# Altium schematic sheet Sheet2.SchDoc(Sheet2)
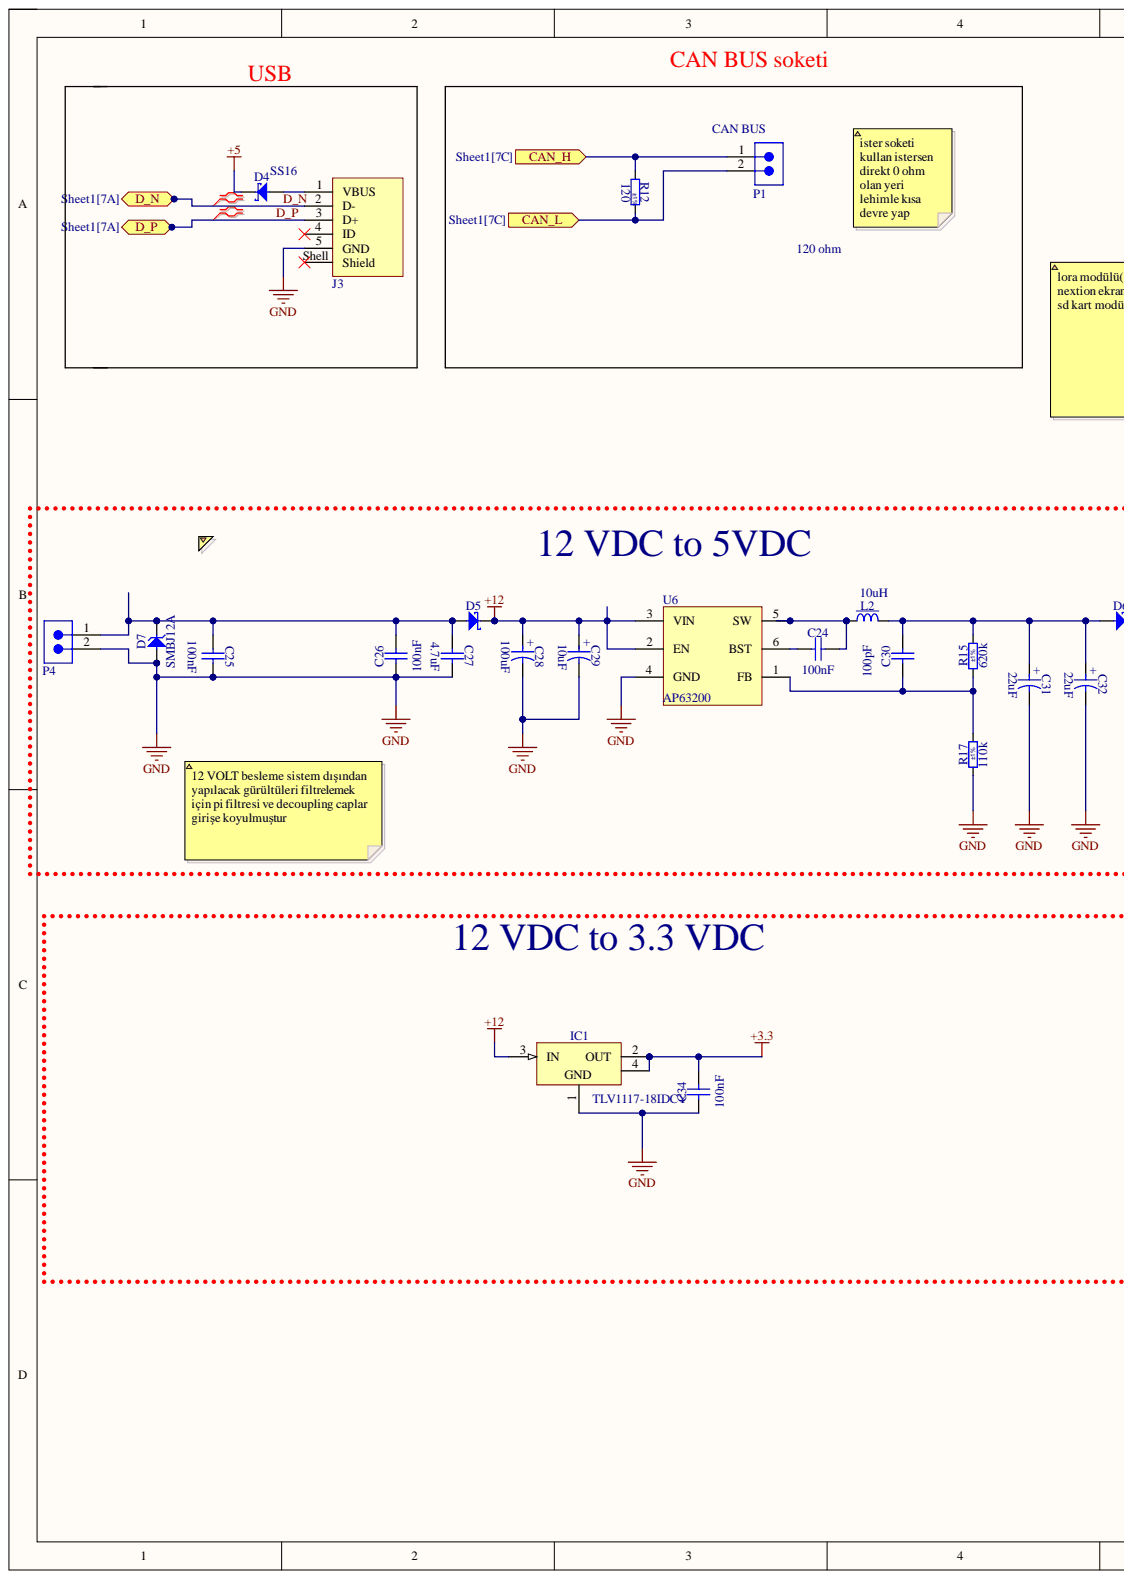
[diagram p0: Sheet2.SchDoc, left]
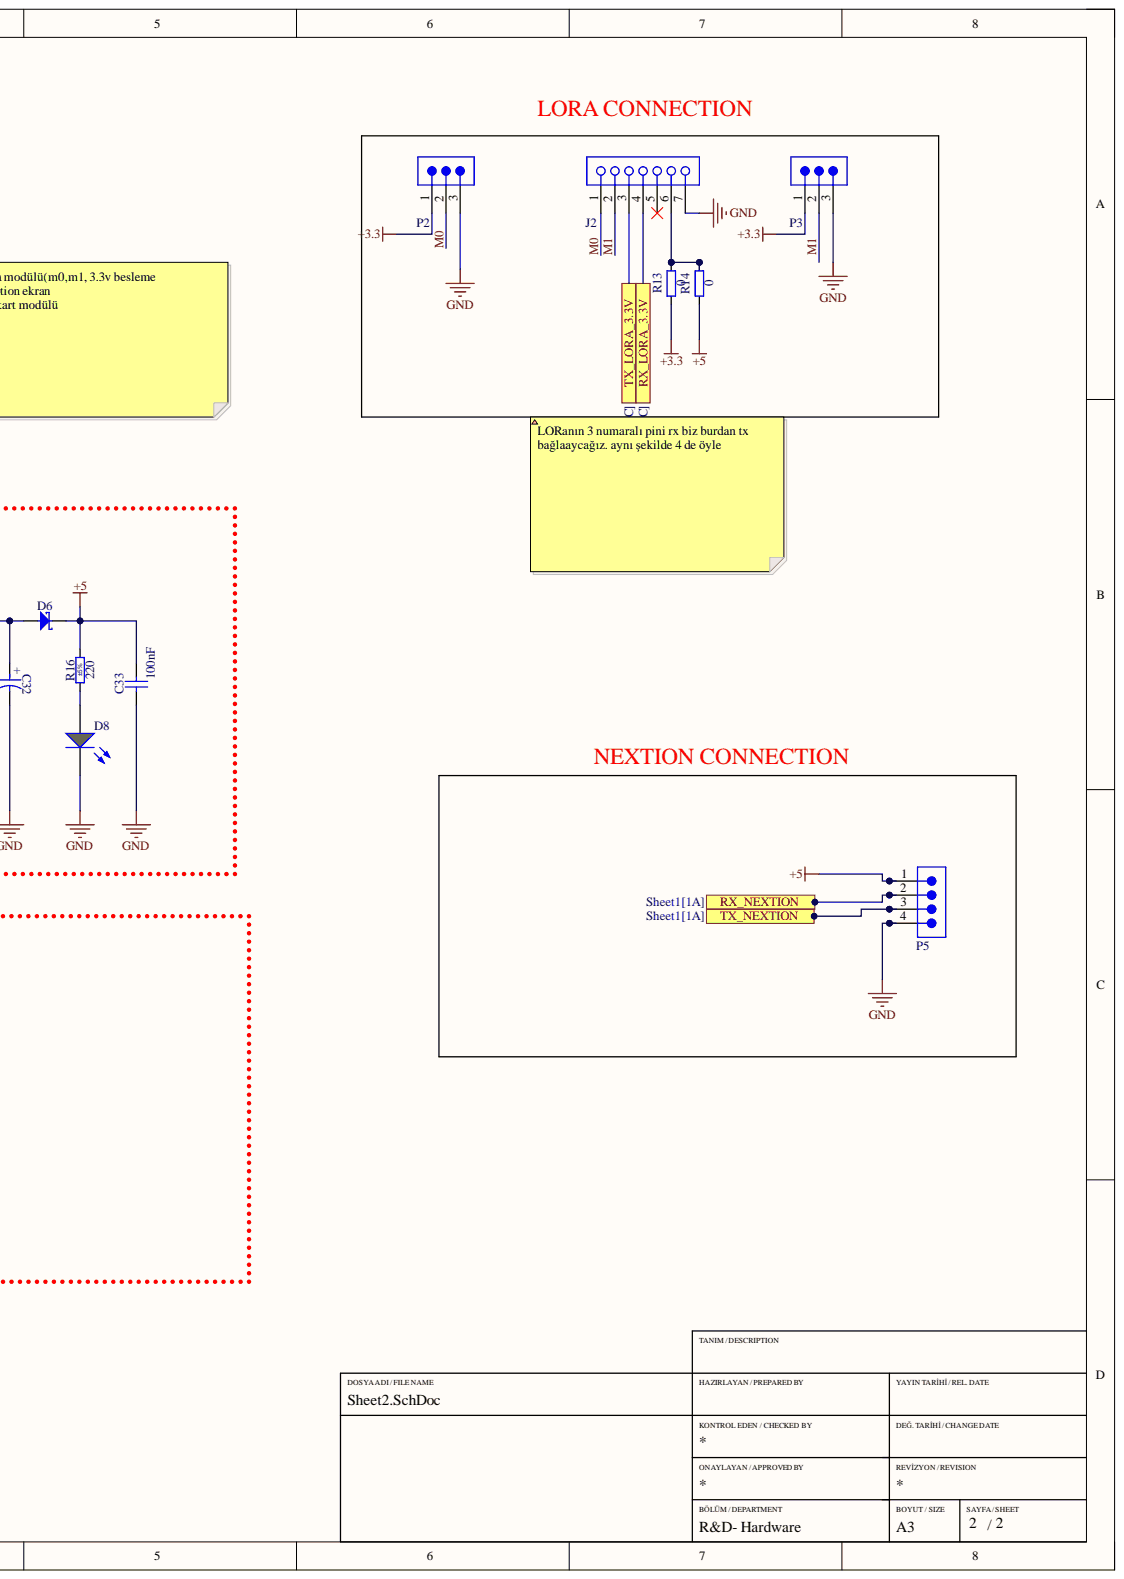
[diagram p1: Sheet2.SchDoc, right]

## Parts
C24  100nF  [CAPC2012X135N]
C25  100nF  [CAPC2012X135N]
C26  100nF  [CAPC2012X135N]
C27  4.7uF  [CAPC2012X135N]
C28  100uF  [CAPPR250-680X1250]
C29  10uF  [CAPPR250-680X1250]
C30  100pF  [CAPC2012X135N]
C31  22uF  [CAPPR250-500X1100]
C32  22uF  [CAPPR250-500X1100]
C33  100nF  [CAPC2012X135N]
C34  100nF  [CAPC2012X135N]
D4  SS16  [DIOM5127X229N]
D5  SS16  [DIOM5127X229N]  (value not drawn)
D6  SS16  [DIOM5127X229N]  (value not drawn)
D7  SMBJ12A  [DIOM5336X265N]
D8  Green, 3.2V, 140°  [WL-SMCW_0805_150080xx75000]  (value not drawn)
IC1  TLV1117-18IDCY  [SOT230P700X180-4N]
J2  61300711821  [61300711821]  (value not drawn)
J3  65100516121  [65100516121]  (value not drawn)
L2  10uH  [IND_TRACO_TCK-047]
P1  691312510002  [691312510002]  (value not drawn)
P2  61300311121  [61300311121]  (value not drawn)
P3  61300311121  [61300311121]  (value not drawn)
P4  691312410002  [691312410002]  (value not drawn)
P5  691312510004  [691312510004]  (value not drawn)
R12  120  Tolerance: ±1%  [RESC2012X60N]
R13  0  [RESC2012X60N]
R14  0  [RESC2012X60N]
R15  620k  Tolerance: ±1%  [RESC2012X60N]
R16  220  Tolerance: ±5%  [RESC2012X60N]
R17  110k  Tolerance: ±1%  [RESC2012X60N]
U6  AP63200  [TSOT-26]

## Ports
CAN_H, CAN_L, D_N, D_P, RX_LORA_3.3V, RX_NEXTION, TX_LORA_3.3V, TX_NEXTION

## Nets
+3.3: C34.2 IC1.2(OUT) IC1.4 P2.1 P3.1 R13.1
+5: C33.2 D4.1 D6.1 P5.1 R14.1 R16.2
+12: C28.1 C29.1 D5.1 IC1.3(IN) U6.2(EN) U6.3(VIN)
GND: C25.2 C26.1 C27.2 C28.2 C29.2 C31.2 C32.2 C33.1 C34.1 D7.2 D8.1 IC1.1 J2.7 J3.5(GND) P2.3 P3.3 P4.2 P5.4 R17.1 U6.4(GND)
CAN_H: P1.1 R12.1 ; port CAN_H
CAN_L: P1.2 R12.2 ; port CAN_L
D_N: J3.2(D-) ; port D_N
D_P: J3.3(D+) ; port D_P
M0: J2.1 P2.2
M1: J2.2 P3.2
RX_LORA_3.3V: J2.4 ; port RX_LORA_3.3V
RX_NEXTION: P5.2 ; port RX_NEXTION
TX_LORA_3.3V: J2.3 ; port TX_LORA_3.3V
TX_NEXTION: P5.3 ; port TX_NEXTION
NetC24_1: C24.1 U6.6(BST)
NetC24_2: C24.2 L2.1 U6.5(SW)
NetC25_1: C25.1 C26.2 C27.1 D5.2 D7.1 P4.1
NetC30_1: C30.1 R15.1 R17.2 U6.1(FB)
NetC30_2: C30.2 C31.1 C32.1 D6.2 L2.2 R15.2
NetD4_2: D4.2 J3.1(VBUS)
NetD8_2: D8.2 R16.1
NetJ2_6: J2.6 R13.2 R14.2

Not connected: J2 5; J3 4(ID) Shell(Shield)

## Library data of the parts
C24-C26 C33 C34 = CC0805_100NF_50V_10%_X7R: Non-polarised capacitor; Manufacturer: GENERIC; Manufacturer1 Part Number: C2012X7R1H104K085; Part Description: SMD Multilayer Chip Ceramic Capacitor; Database Table Name: Capacitors SMD; TC: X7R; Tolerance: ±10%; Voltage: 50V; Case: 0805; Component Kind: Standard; Component Type: Standard; CreateDate: 3.12.2007; HelpURL: \\cern.ch\dfs\Applications\Altium\Datasheets\CC0805_X7R_TDK_C.pdf; LatestRevisionDate: 22.04.2015; Library Name: Capacitors.DbLib; Manufacturer1 ComponentHeight: 1mm; Manufacturer1 Example: TDK; Manufacturer Part Number: CC0805_100NF_50V_10%_X7R; Mounted: Yes; Part Number: CC0805_100NF_50V_10%_X7R; Pin Count: 2; Sense: No; SMD: Yes; Socket: No; Status: None; Value: 100nF; Author: CERN DEM JLC
C27 = CC0805_4.7UF_10V_10%_X5R: Non-polarised capacitor; Manufacturer: GENERIC; Manufacturer1 Part Number: 222224013672; Part Description: SMD Multilayer Chip Ceramic Capacitor; Database Table Name: Capacitors SMD; TC: X5R; Tolerance: ±10%; Voltage: 10V; Case: 0805; Component Kind: Standard; Component Type: Standard; CreateDate: 3.12.2007; HelpURL: \\cern.ch\dfs\Applications\Altium\Datasheets\CC0805_X5R_PHYCOMP_HC.pdf; LatestRevisionDate: 3.12.2007; Library Name: Capacitors.DbLib; Manufacturer1 ComponentHeight: 1.45mm; Manufacturer1 Example: PHYCOMP; Manufacturer Part Number: CC0805_4.7UF_10V_10%_X5R; Mounted: Yes; Part Number: CC0805_4.7UF_10V_10%_X5R; Pin Count: 2; Sense: No; SMD: Yes; Socket: No; Status: Preferred; Value: 4.7uF; Author: CERN DEM JLC
C28 = CAER_100UF_25V_20%_NICHICON_UPS1E101MED: Polarised capacitor; Part Description: Aluminium Electrolytic Capacitor (UPS Series); Database Table Name: Capacitors THD; Tolerance: ±20%; Voltage: 25V; Case: LS2.5 D6.3 x H11; Component Kind: Standard; Component Type: Standard; ComponentHeight: 12.5mm; CreateDate: 30.05.2016; HelpURL: \\cern.ch\dfs\Applications\Altium\Datasheets\CAER_NICHICON_UPS.pdf; LatestRevisionDate: 30.05.2016; Library Name: Capacitors.DbLib; Manufacturer: NICHICON; Manufacturer Part Number: UPS1E101MED; Mounted: Yes; Part Number: CAER_100UF_25V_20%_NICHICON_UPS1E101MED; Pin Count: 2; Sense: No; SMD: No; Socket: No; Status: None; Value: 100uF; Author: CERN DEM MR
C29 = CAER_100UF_25V_20%_NICHICON_UPS1E101MED: Polarised capacitor; Part Description: Aluminium Electrolytic Capacitor (UPS Series); Part Number: CAER_100NF_25V_20%_NICHICON_UPS1E101MED; Database Table Name: Capacitors THD; Tolerance: ±20%; Voltage: 25V; Case: LS2.5 D6.3 x H11; Component Kind: Standard; Component Type: Standard; ComponentHeight: 12.5mm; CreateDate: 30.05.2016; HelpURL: \\cern.ch\dfs\Applications\Altium\Datasheets\CAER_NICHICON_UPS.pdf; LatestRevisionDate: 30.05.2016; Library Name: Capacitors.DbLib; Manufacturer: NICHICON; Manufacturer Part Number: UPS1E101MED; Mounted: Yes; Pin Count: 2; Sense: No; SMD: No; Socket: No; Status: None; Value: 10uF; Author: CERN DEM MR
C30 = CC0805_100PF_50V_5%_NP0: Non-polarised capacitor; Manufacturer: GENERIC; Manufacturer1 Part Number: 223886115101; Part Description: SMD Multilayer Chip Ceramic Capacitor; Database Table Name: Capacitors SMD; TC: C0G/NP0; Tolerance: ±5%; Voltage: 50V; Case: 0805; Component Kind: Standard; Component Type: Standard; CreateDate: 3.12.2007; HelpURL: \\cern.ch\dfs\Applications\Altium\Datasheets\CC0805_NP0_PHYCOMP.pdf; LatestRevisionDate: 3.12.2007; Library Name: Capacitors.DbLib; Manufacturer1 ComponentHeight: 1.35mm; Manufacturer1 Example: PHYCOMP; Manufacturer Part Number: CC0805_100PF_50V_5%_NP0; Mounted: Yes; Part Number: CC0805_100PF_50V_5%_NP0; Pin Count: 2; Sense: No; SMD: Yes; Socket: No; Status: Preferred; Value: 100pF; Author: CERN DEM JLC
C31 = CAER_47UF_25V_20%_250-500X1100: Polarised capacitor; Manufacturer: GENERIC; Manufacturer1 Part Number: EEUFC1E470; Manufacturer Part Number: CAER_22uF_25V_20%_250-500X1100; Part Description: Aluminum Electrolytic Capacitor; Database Table Name: Capacitors THD; Tolerance: ±20%; Voltage: 25V; Case: LS2.5 D5 x H11; Component Kind: Standard; Component Type: Standard; ComponentHeight: 11mm; CreateDate: 18.12.2007; HelpURL: \\cern.ch\dfs\Applications\Altium\Datasheets\CAER_PANASONIC_FC.pdf; LatestRevisionDate: 18.12.2007; Library Name: Capacitors.DbLib; Manufacturer1 ComponentHeight: 11mm; Manufacturer1 Example: PANASONIC; Mounted: Yes; Part Number: CAER_22uF_25V_20%_250-500X1100; Pin Count: 2; Sense: No; SMD: No; Socket: No; Status: Preferred; Value: 22uF; Author: CERN DEM JLC
C32 = CAER_47UF_25V_20%_250-500X1100: Polarised capacitor; Manufacturer: GENERIC; Manufacturer1 Part Number: EEUFC1E470; Manufacturer Part Number: CAER_22pF_25V_20%_250-500X1100; Part Description: Aluminum Electrolytic Capacitor; Part Number: CAER_22uF_25V_20%_250-500X1100; Database Table Name: Capacitors THD; Tolerance: ±20%; Voltage: 25V; Case: LS2.5 D5 x H11; Component Kind: Standard; Component Type: Standard; ComponentHeight: 11mm; CreateDate: 18.12.2007; HelpURL: \\cern.ch\dfs\Applications\Altium\Datasheets\CAER_PANASONIC_FC.pdf; LatestRevisionDate: 18.12.2007; Library Name: Capacitors.DbLib; Manufacturer1 ComponentHeight: 11mm; Manufacturer1 Example: PANASONIC; Mounted: Yes; Pin Count: 2; Sense: No; SMD: No; Socket: No; Status: Preferred; Value: 22uF; Author: CERN DEM JLC
D4-D6 = SS16: Schottky Diode; Manufacturer: VISHAY GENERAL SEMICONDUCTOR; Part Description: Surface Mount Schottky Barrier Rectifier; Bonding: No; Database Table Name: Diodes; Power: 1A; PressFit: No; Voltage: 60V; Case: DO-214AC; Component Kind: Standard; Component Type: Standard; ComponentHeight: 2.29mm; CreateDate: 15.07.2008; Device: SS16; HelpURL: \\cern.ch\dfs\Applications\Altium\Datasheets\SS16.pdf; LatestRevisionDate: 23.08.2010; Library Name: ICs And Semiconductors.DbLib; Manufacturer Part Number: SS16; Mounted: Yes; PackageDescription: DO-214-AC/SMA Diode, Molded Body; 5.10mm L X 2.67mm W X 2.29mm H, IPC Medium Density; Part Number: SS16; Pin Count: 2; Sense: No; SMD: Yes; Socket: No; Author: CERN DEM JLC
D7 = SMBJ12A: Zener Diode; Manufacturer: FAIRCHILD SEMICONDUCTOR; Part Description: Uni-Directional Transient Voltage Suppressor Diode; Bonding: No; Database Table Name: Diodes; Power: 600W; PressFit: No; Voltage: 12V; Case: DO-214AA; Component Kind: Standard; Component Type: Standard; ComponentHeight: 2.65mm; CreateDate: 10.04.2013; Device: SMBJ12A; HelpURL: \\cern.ch\dfs\Applications\Altium\Datasheets\SMBJ.pdf; LatestRevisionDate: 10.04.2013; Library Name: ICs And Semiconductors.DbLib; Manufacturer Part Number: SMBJ12A; Mounted: Yes; PackageDescription: DO-214-AA/SMB Diode, Molded Body; 5.34mm L X 3.625mm W X 2.65mm H, IPC Medium Density; Part Number: SMBJ12A; Pin Count: 2; Sense: No; SMD: Yes; Socket: No; Author: CERN DEM JLC
D8 = 150080GS75000: WL-SMCW Mono-color Chip LED Waterclear, size 0805, Green, 3.2V, 140°; Manufacturer: Wurth Elektronik; Case/Size Code: 0805; Chip Technology: InGaN; Database Table Name: LEDs; Dominant Wavelength: 525nm; Luminous Intensity: 450mcd; Peak Wavelength: 515nm; Category: Signal LEDs; Component Kind: Standard; ComponentLink1Description: Website Link; ComponentLink1URL: https://www.we-online.com/redexpert/article/150080GS75000?ad; ComponentLink2Description: Datasheet Link; ComponentLink2URL: https://www.we-online.com/redexpert/spec/150080GS75000?ad; Emitting Color: Green; Forward Voltage: 3.2V; Height: 0.7mm; Length: 2mm; Library Name: WE - Optoelectronic Components.DbLib; Manufacturer Part Number: 150080GS75000; Match Code: WL-SMCW; Mount: Surface Mount; Operating Temperature Max: 85°C; Operating Temperature Min: -40°C; Packaging: Tape and Reel; Simulation: 0805_150080GS75000; Viewing Angle: 140°; Width: 1.25mm
IC1 = TLV1117-18IDCY: 3-Terminal Regulator 1=GND 2=OUT 3=IN 4=OUT; Manufacturer: TEXAS INSTRUMENTS; Part Description: 1.8V, 800mA Low-Dropout Voltage Regulator; Bonding: No; Database Table Name: Regulators; PressFit: No; Case: SOT223; Component Kind: Standard; Component Type: Standard; ComponentHeight: 1.8mm; CreateDate: 6.06.2017; Device: TLV1117-18IDCY; HelpURL: \\cern.ch\dfs\Applications\Altium\Datasheets\TLV1117-18IDCY.pdf; LatestRevisionDate: 6.06.2017; Library Name: ICs And Semiconductors.DbLib; Manufacturer Part Number: TLV1117-18IDCY; Mounted: Yes; PackageDescription: SOT223, 2.30mm Pitch; 4 Pin, 3.70mm W X 6.70mm L X 1.80mm H body, IPC Medium Density; Part Number: TLV1117-18IDCY; Pin Count: 4; Sense: No; SMD: Yes; Socket: No; Author: CERN DEM JLC
J2 = 61300711821: WR-PHD Socket Header, THT, Vertical, pitch 2.54mm, 1 Row, 7P; Manufacturer: Wurth Elektronik; Contact Resistance: 20mOhm; Database Table Name: Connectors; Rated Current: 3A; Withstanding Voltage: 500V; Working Voltage: 250V; Category: Board-to-Board Connectors; Component Kind: Standard; ComponentLink1Description: Website Link; ComponentLink1URL: https://www.we-online.com/redexpert/article/61300711821?ad; ComponentLink2Description: Datasheet Link; ComponentLink2URL: https://www.we-online.com/redexpert/spec/61300711821?ad; Gender: Socket Header; Library Name: WE - Electromechanical Components.DbLib; Manufacturer Part Number: 61300711821; Match Code: WR-PHD; Mount: Through Hole; Number of Pins: 7; Number of Rows: 1; Operating Temperature Max: 125°C; Operating Temperature Min: -40°C; Orientation: Vertical; Packaging: Tray; Pitch: 2.54mm
J3 = 65100516121: WR-COM Mini USB 2.0 Type B SMT Horizontal 5 Contacts; Manufacturer: Wurth Elektronik; Database Table Name: Connectors; Gender: Receptacle; Match Code: WR-USB; Number of Ports: 1; Rated Current: 1A; Working Voltage: 30V (AC); Interface Type: Type B; Application: Mini USB 2.0; Category: Input/Output Connectors; Component Kind: Standard; ComponentLink1Description: Website Link; ComponentLink1URL: https://www.we-online.com/redexpert/article/65100516121?ad; ComponentLink2Description: Datasheet Link; ComponentLink2URL: https://www.we-online.com/redexpert/spec/65100516121?ad; Library Name: WE - Electromechanical Components.DbLib; Manufacturer Part Number: 65100516121; Mount: Surface Mount; Number of Pins: 5; Operating Temperature Max: 105°C; Operating Temperature Min: -40°C; Orientation: Horizontal; Packaging: Tape and Reel & Mylar
L2 = IND_10UH_20%_TRACO_TCK-047: Inductor With Core; Manufacturer: TRACO POWER; Part Description: SMD Common Mode Choke; Database Table Name: Inductors SMD; Power: 1.44A; Resistance: 0.1R; Tolerance: ±20%; Component Kind: Standard; Component Type: Standard; ComponentHeight: 4.85mm; CreateDate: 16.11.2017; HelpURL: \\cern.ch\dfs\Applications\Altium\Datasheets\IND_TRACO_TCK-047.pdf; LatestRevisionDate: 16.11.2017; Library Name: Inductors & Transformers.DbLib; Manufacturer Part Number: TCK-047; Mounted: Yes; Part Number: IND_10UH_20%_TRACO_TCK-047; Pin Count: 2; Sense: No; SMD: Yes; Socket: No; Value: 10uH; Author: CERN DEM JLC
P1 = 691312510002: WR-TBL Series 312 Open Horizontal PCB Header, THT, pitch 5.08mm, 2p; Manufacturer: Wurth Elektronik; Database Table Name: Connectors; Modularity: No; Rated Current: 20A; Working Voltage: 300V; Application: Pluggable; Category: Terminal Blocks; Component Kind: Standard; ComponentLink1Description: Website Link; ComponentLink1URL: https://www.we-online.com/redexpert/article/691312510002?ad; ComponentLink2Description: Datasheet Link; ComponentLink2URL: https://www.we-online.com/redexpert/spec/691312510002?ad; Library Name: WE - Electromechanical Components.DbLib; Manufacturer Part Number: 691312510002; Match Code: WR-TBL; Mount: Through Hole; Number of Pins: 2; Number of Rows: 1; Operating Temperature Max: 105°C; Operating Temperature Min: -40°C; Orientation: Horizontal; Packaging: Box; Pitch: 5.08mm
P2 P3 = 61300311121: WR-PHD Pin Header, THT, Vertical, pitch 2.54mm, 1 Row, 3P; Manufacturer: Wurth Elektronik; Contact Resistance: 20mOhm; Database Table Name: Connectors; Rated Current: 3A; Withstanding Voltage: 500V; Working Voltage: 250V; Category: Board-to-Board Connectors; Component Kind: Standard; ComponentLink1Description: Website Link; ComponentLink1URL: https://www.we-online.com/redexpert/article/61300311121?ad; ComponentLink2Description: Datasheet Link; ComponentLink2URL: https://www.we-online.com/redexpert/spec/61300311121?ad; Gender: Pin Header; Library Name: WE - Electromechanical Components.DbLib; Manufacturer Part Number: 61300311121; Match Code: WR-PHD; Mount: Through Hole; Number of Pins: 3; Number of Rows: 1; Operating Temperature Max: 105°C; Operating Temperature Min: -40°C; Orientation: Vertical; Packaging: Bag; Pitch: 2.54mm
P4 = 691312410002: WR-TBL Series 3124 Open Horizontal PCB Header, THT, pitch 7.62mm, 2p; Manufacturer: Wurth Elektronik; Database Table Name: Connectors; Modularity: No; Rated Current: 20A; Working Voltage: 300V; Application: Pluggable; Category: Terminal Blocks; Component Kind: Standard; ComponentLink1Description: Website Link; ComponentLink1URL: https://www.we-online.com/redexpert/article/691312410002?ad; ComponentLink2Description: Datasheet Link; ComponentLink2URL: https://www.we-online.com/redexpert/spec/691312410002?ad; Library Name: WE - Electromechanical Components.DbLib; Manufacturer Part Number: 691312410002; Match Code: WR-TBL; Mount: Through Hole; Number of Pins: 2; Number of Rows: 1; Operating Temperature Max: 105°C; Operating Temperature Min: -40°C; Orientation: Horizontal; Packaging: Box; Pitch: 7.62mm
P5 = 691312510004: WR-TBL Series 312 Open Horizontal PCB Header, THT, pitch 5.08mm, 4p; Manufacturer: Wurth Elektronik; Database Table Name: Connectors; Modularity: No; Rated Current: 20A; Working Voltage: 300V; Application: Pluggable; Category: Terminal Blocks; Component Kind: Standard; ComponentLink1Description: Website Link; ComponentLink1URL: https://www.we-online.com/redexpert/article/691312510004?ad; ComponentLink2Description: Datasheet Link; ComponentLink2URL: https://www.we-online.com/redexpert/spec/691312510004?ad; Library Name: WE - Electromechanical Components.DbLib; Manufacturer Part Number: 691312510004; Match Code: WR-TBL; Mount: Through Hole; Number of Pins: 4; Number of Rows: 1; Operating Temperature Max: 105°C; Operating Temperature Min: -40°C; Orientation: Horizontal; Packaging: Box; Pitch: 5.08mm
R12 = R0805_120R_1%_0.125W_100PPM: Resistor - 1%; Manufacturer: GENERIC; Manufacturer1 Part Number: NRC10F1200TRF; Part Description: General Purpose Thick Film Chip Resistor; Database Table Name: Resistors SMD; Power: 0.125W; PressFit: No; TC: ±100ppm/°C; Case: 0805; Component Kind: Standard; Component Type: Standard; CreateDate: 3.12.2007; HelpURL: \\cern.ch\dfs\Applications\Altium\Datasheets\R0805_NIC_NRC.pdf; LatestRevisionDate: 13.03.2008; Library Name: Resistors.DbLib; Manufacturer1 ComponentHeight: 0.6mm; Manufacturer1 Example: NIC COMPONENT; Manufacturer Part Number: R0805_120R_1%_0.125W_100PPM; Mounted: Yes; Part Number: R0805_120R_1%_0.125W_100PPM; Pin Count: 2; Sense: No; SMD: Yes; Socket: No; Status: Preferred; Value: 120; Author: CERN DEM JLC
R13 R14 = R0805_0R_JUMPER: Resistor; Manufacturer: GENERIC; Manufacturer1 Part Number: NRC10Z0; Part Description: 2A (0.05R Max DC Resistance) Zero Ohm Jumper; Database Table Name: Resistors SMD; PressFit: No; Case: 0805; Component Kind: Standard; Component Type: Standard; CreateDate: 3.12.2007; HelpURL: \\cern.ch\dfs\Applications\Altium\Datasheets\R0805_NIC_NRC.pdf; LatestRevisionDate: 13.03.2008; Library Name: Resistors.DbLib; Manufacturer1 ComponentHeight: 0.6mm; Manufacturer1 Example: NIC COMPONENT; Manufacturer Part Number: R0805_0R_JUMPER; Mounted: Yes; Part Number: R0805_0R_JUMPER; Pin Count: 2; Sense: No; SMD: Yes; Socket: No; Status: Preferred; Value: 0; Author: CERN DEM JLC
R15 = R0805_62K_1%_0.125W_100PPM: Resistor - 1%; Manufacturer: GENERIC; Manufacturer1 Part Number: NRC10F6202TRF; Part Description: General Purpose Thick Film Chip Resistor; Database Table Name: Resistors SMD; Power: 0.125W; PressFit: No; TC: ±100ppm/°C; Case: 0805; Component Kind: Standard; Component Type: Standard; CreateDate: 3.12.2007; HelpURL: \\cern.ch\dfs\Applications\Altium\Datasheets\R0805_NIC_NRC.pdf; LatestRevisionDate: 13.03.2008; Library Name: Resistors.DbLib; Manufacturer1 ComponentHeight: 0.6mm; Manufacturer1 Example: NIC COMPONENT; Manufacturer Part Number: R0805_62K_1%_0.125W_100PPM; Mounted: Yes; Part Number: R0805_62K_1%_0.125W_100PPM; Pin Count: 2; Sense: No; SMD: Yes; Socket: No; Status: Preferred; Value: 620k; Author: CERN DEM JLC
R16 = R0805_680R_1%_0.125W_100PPM: Resistor - 5%; Manufacturer: GENERIC; Manufacturer1 Part Number: NRC10F6800TRF; Manufacturer Part Number: R0805_680R_5%_0.125W_100PPM; Part Description: General Purpose Thick Film Chip Resistor; Database Table Name: Resistors SMD; Power: 0.125W; PressFit: No; TC: ±100ppm/°C; Case: 0805; Component Kind: Standard; Component Type: Standard; CreateDate: 3.12.2007; HelpURL: \\cern.ch\dfs\Applications\Altium\Datasheets\R0805_NIC_NRC.pdf; LatestRevisionDate: 13.03.2008; Library Name: Resistors.DbLib; Manufacturer1 ComponentHeight: 0.6mm; Manufacturer1 Example: NIC COMPONENT; Mounted: Yes; Part Number: R0805_680R_5%_0.125W_100PPM; Pin Count: 2; Sense: No; SMD: Yes; Socket: No; Status: Preferred; Value: 220; Author: CERN DEM JLC
R17 = R0805_11K_1%_0.125W_100PPM: Resistor - 1%; Manufacturer: GENERIC; Manufacturer1 Part Number: NRC10F1102TRF; Part Description: General Purpose Thick Film Chip Resistor; Database Table Name: Resistors SMD; Power: 0.125W; PressFit: No; TC: ±100ppm/°C; Case: 0805; Component Kind: Standard; Component Type: Standard; CreateDate: 3.12.2007; HelpURL: \\cern.ch\dfs\Applications\Altium\Datasheets\R0805_NIC_NRC.pdf; LatestRevisionDate: 13.03.2008; Library Name: Resistors.DbLib; Manufacturer1 ComponentHeight: 0.6mm; Manufacturer1 Example: NIC COMPONENT; Manufacturer Part Number: R0805_11K_1%_0.125W_100PPM; Mounted: Yes; Part Number: R0805_11K_1%_0.125W_100PPM; Pin Count: 2; Sense: No; SMD: Yes; Socket: No; Status: Preferred; Value: 110k; Author: CERN DEM JLC
U6 = AP63200: AP63200 Series 1.2 V 2 A Adjustable Synchronous Buck Converter - TSOT-26; Manufacturer: Diodes; Manufacturer Part Number: AP63200WU-7; Category: Voltage Regulators - Switching; Component Kind: Standard; ComponentLink1Description: https://www.diodes.com/assets/Datasheets/AP63200-AP63201-AP63203-AP63205.pdf?src-supplier=Digikey; ComponentLink1URL: https://www.diodes.com/assets/Datasheets/AP63200-AP63201-AP63203-AP63205.pdf?src-supplier=Digikey; Pricing 1: 3000=0.285, 6000=0.28, 9000=0.275, 12000=0.275, 15000=0.27 (USD); RoHS: Yes; Stock 1: 9000; Supplier 1: Future Electronics; Supplier Part Number 1: 2108618; Library Name: iku_mesut.IntLib
